# Altium schematic sheet LightBarrier.SchDoc(LightBarrier)
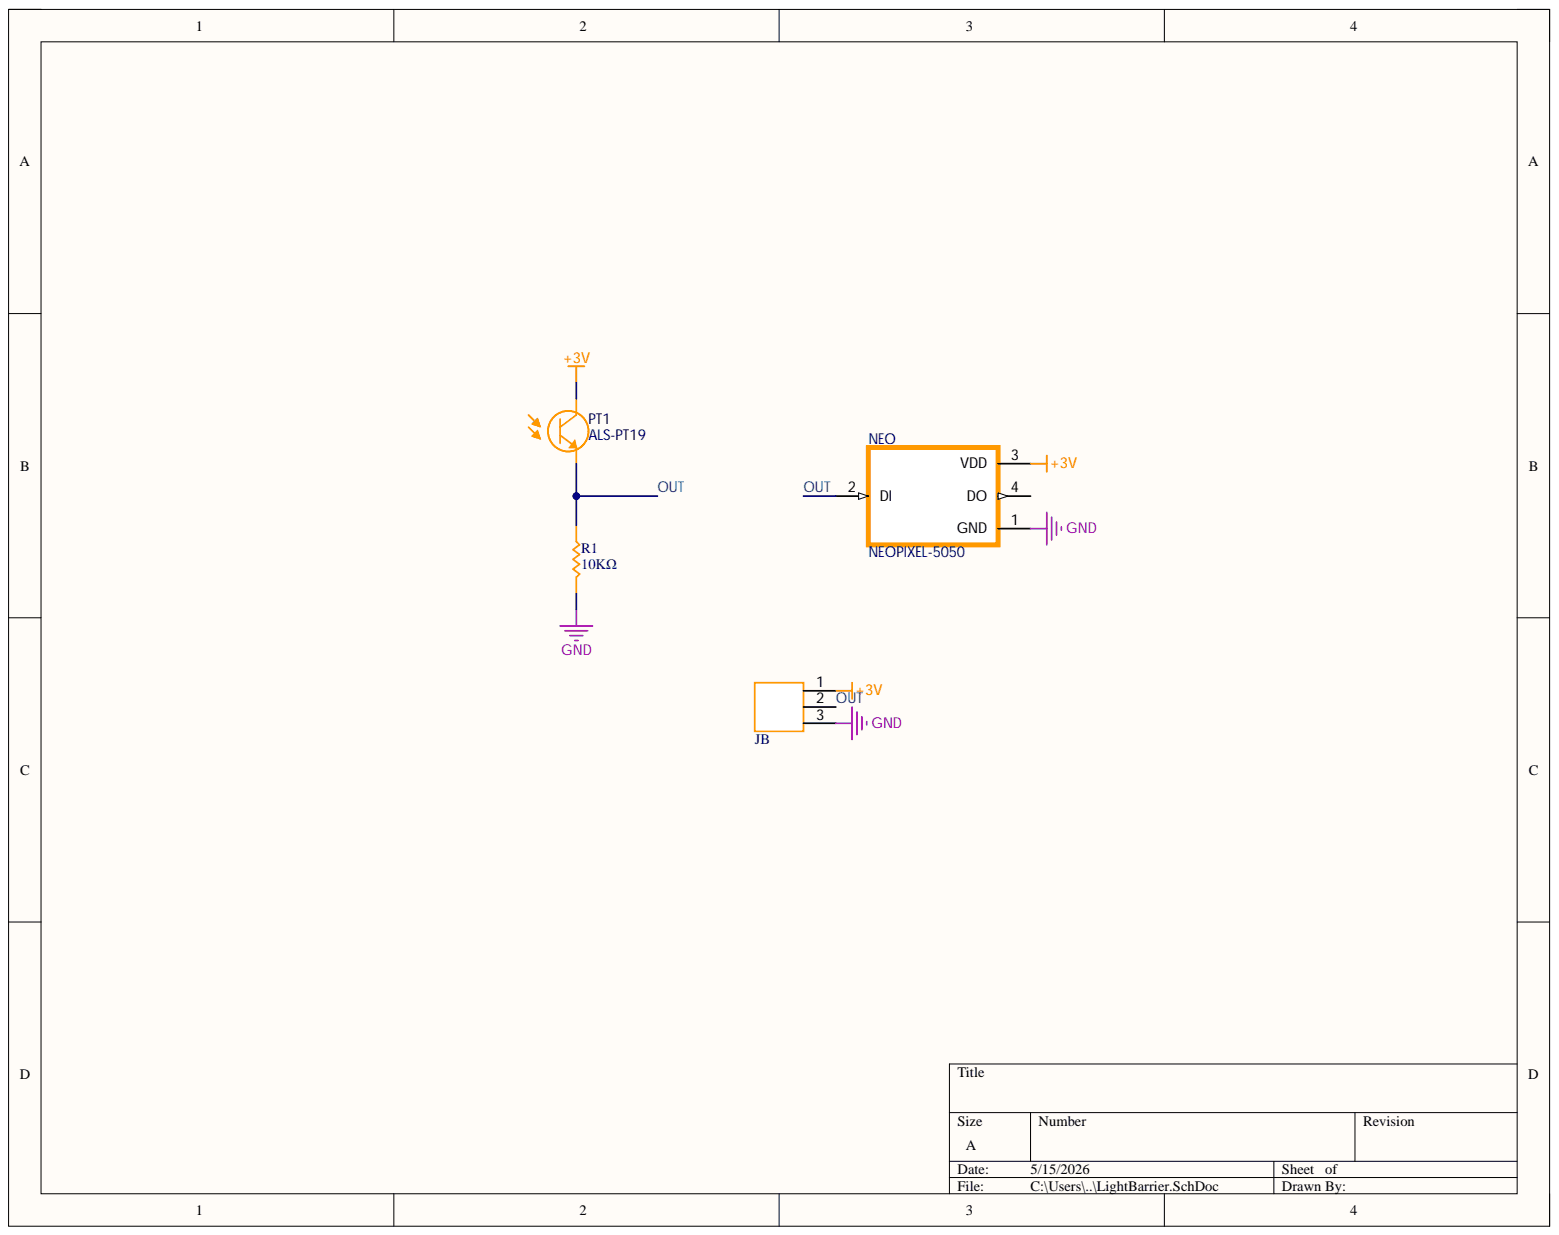
[diagram p0: LightBarrier.SchDoc]

## Parts
JB  3PIN  [3PIN]  (value not drawn)
NEO  NEOPIXEL-5050  [NEOPIXEL-5050]
PT1  ALS-PT19  [ALS-PT19]
R1  10KΩ  [RES_0603]

## Nets
+3V: JB.1 NEO.3(VDD) PT1.C
GND: JB.3 NEO.1(GND) R1.1
OUT: JB.2 NEO.2(DI) PT1.E R1.2

Not connected: NEO 4(DO)

## Library data of the parts
JB = 3PIN: 2.54 Header; Component Kind: Standard; Library Name: RC.IntLib
R1 = RES_0603: Resistor SMD 0603 generic; Component Kind: Standard; Library Name: RC.IntLib
NEO = NEOPIXEL-5050: Component Kind: Standard; Library Name: RC.IntLib
PT1 = ALS-PT19: Component Kind: Standard; Library Name: RC.IntLib
